# Altium schematic sheet THR_V1.SchDoc(THR_V1)
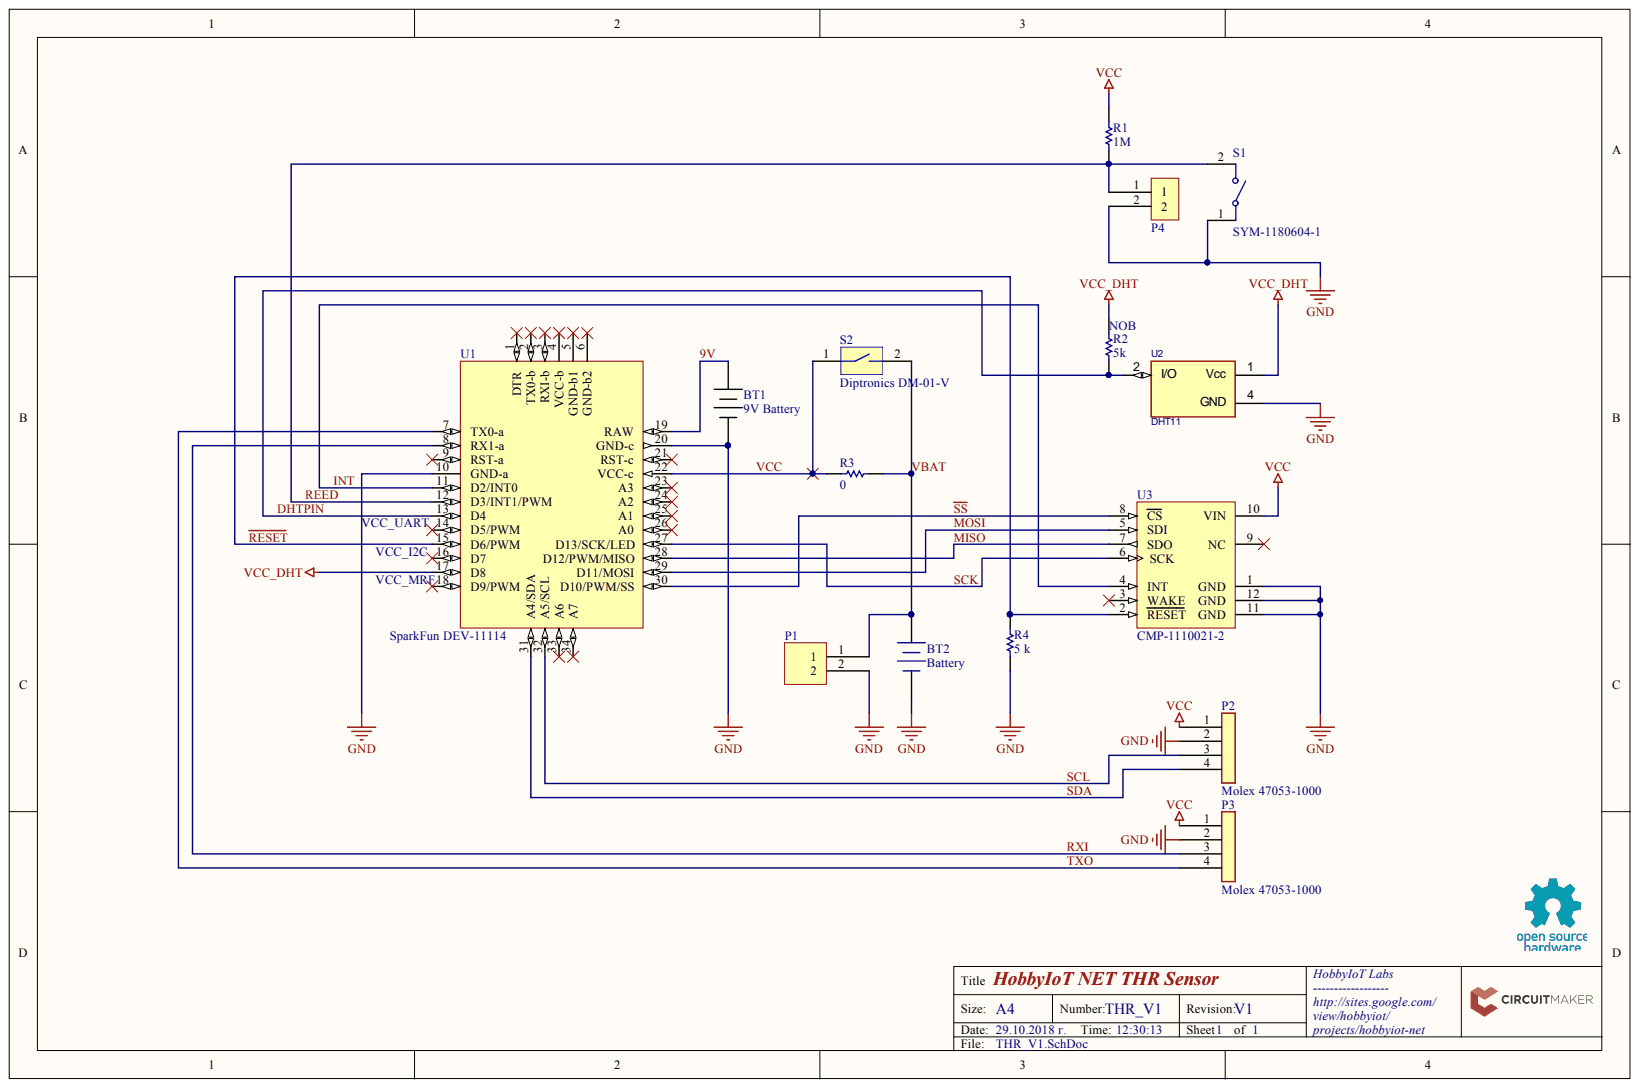
[diagram p0: THR_V1.SchDoc]

## Parts
BT1  9V Battery  [PCB-b0ysiftp69igi77ywnbr-1]
BT2  Battery  [108-holes]
P1  JST B2B-PH-K-S(LF)(SN)  [PCB-gpdi66yn1jko56crxrq7-1]  (value not drawn)
P2  Molex 47053-1000  [PCB-r6ljhe4gry6dgah4l7og-1]
P3  Molex 47053-1000  [PCB-r6ljhe4gry6dgah4l7og-1]
P4  TE Connectivity 87220-2  [2 POS HDR]  (value not drawn)
R1  1M  [RESC1608X55X30LL15T15]
R2  5k  [RESC1608X55X30LL15T15]
R3  0  [RESC1608X55X30LL15T15]
R4  5 k  [RESC1608X55X30LL15T15]
S1  SYM-1180604-1  [PCB-st9at6ls1ff1te26vloo-1]
S2  Diptronics DM-01-V  Manufacturer Part Number: DM-01-V  [PCB-hx7lme7bhq0swr2xcdxd-1]
U1  SparkFun DEV-11114  Manufacturer Part Number: DEV-11114  [PCBComponent_1 - duplicate]
U2  DHT11  [PCB-2j4abk0z7nv5d9pbfq6c-1]
U3  CMP-1110021-2  [MRF24J40MA]

## Nets
GND: BT1.2 BT2.2 P1.2 P2.2 P3.2 P4.2 R4.1 S1.1 U1.10(GND-a) U1.20(GND-c) U2.4 U3.1(GND) U3.11(GND) U3.12(GND)
VCC_DHT: R2.2 U1.17(D8) U2.1
9V: BT1.1 U1.19(RAW)
DHTPIN: R2.1 U1.13(D4) U2.2
INT: U1.11(D2/INT0) U3.4(INT)
MISO: U1.28(D12/PWM/MISO) U3.7(SDO)
MOSI: U1.29(D11/MOSI) U3.5(SDI)
~{RESET}: R4.2 U1.15(D6/PWM) U3.2(RESET)
REED: P4.1 R1.1 S1.2 U1.12(D3/INT1/PWM)
RXI: P3.3 U1.8(RX1-a)
~{SS}: U1.30(D10/PWM/SS) U3.8(CS)
SCK: U1.27(D13/SCK/LED) U3.6(SCK)
SCL: P2.3 U1.32(A5/SCL)
SDA: P2.4 U1.31(A4/SDA)
TXO: P3.4 U1.7(TX0-a)
VBAT: BT2.1 P1.1 R3.1 S2.2
VCC: P2.1 P3.1 R1.2 R3.2 S2.1 U1.22(VCC-c) U3.10(VIN)

Not connected: U1 1(DTR) 2(TX0-b) 3(RXI-b) 4(VCC-b) 5(GND-b1) 6(GND-b2) 9(RST-a) 14(D5/PWM) 16(D7) 18(D9/PWM) 21(RST-c) 23(A3) 24(A2) 25(A1) 26(A0) 33(A6) 34(A7); U3 3(WAKE) 9(NC)

## Library data of the parts
BT1 = CMP-fb36323cdf4924f1-5: Manufacturer Part Number: EN22; Jacket Material: Metal; Lead-Free Status: Contains Lead; Output Voltage: 9.00 V; Component Kind: Standard; Description: 9v Battery; Library Name: CommunityVault; OctopartID: fb36323cdf4924f1; Octopart URL: http://octopart.com/en22-energizer-281; REACH SVHC Compliance: No SVHC; RoHS: Not Applicable; Size-Height: 46.4 mm; Size-Width: 17.5 mm; Voltage Rating (DC): 9.00 V; Weight: 45.4 g
BT2 = CMP-a46e6d64aa3a7ba9-5: Cell holder for CR2 and ½AA cells; Manufacturer Part Number: 108; Lead-Free Status: Lead Free; Component Kind: Standard; Contact Material: Steel; Library Name: CommunityVault; Material: Plastic; Mounting Style: Through Hole, PCB, Snap-In; Octopart URL: http://octopart.com/108-keystone-11058; REACH SVHC Compliance: No SVHC; RoHS: Compliant
P1 = CMP-642947-7: PH Series 2 Position 2 mm Pitch Through Hole Top Entry Shrouded Header; Contact Resistance: 10.0 mΩ; Current Rating: 2.00 A; Gender: Male; Insulation Resistance: 1.00 MΩ; Lead-Free Status: Lead Free; Number of Contacts: 2; Pin Pitch: 2.00 mm; Voltage Rating (AC): 100 V; Component Kind: Standard; Contact Material: Brass; Contact Plating: Tin; Housing Color: Natural; Housing Material: Nylon; Library Name: CommunityVault; Manufacturer Part Number: B2B-PH-K-S(LF)(SN); Mounting Style: Through Hole, Vertical; Number of Positions: 2; Number of Rows: 1; OctopartID: a21c6b154b5e7ddb; Octopart URL: http://octopart.com/b2b-ph-k-s%28lf%29%28sn%29-jst-248868; Packaging: Bulk; REACH SVHC Compliance: No SVHC; RoHS: Compliant; Size-Height: 6.00 mm; Size-Length: 5.90 mm; Size-Width: 4.50 mm
P2 P3 = CMP-b5a27ec055876a65-1: Conn; Rect; Header; Unshrouded; 4 Pos; 2.54mm Pitch; 1-Row; Thru-Hole; BergStik; Manufacturer Part Number: 68002-404HLF; Gender: Male; Component Kind: Standard; Library Name: CommunityVault; Mounting Style: Solder; OctopartID: b5a27ec055876a65; Octopart URL: http://octopart.com/68002-404hlf-fci-40420675; RoHS: Compliant
P4 = CMP-f83d336e9dea27fa-9: Header; Standard; PC Board; Single; 2; 0.025 in.; 0.125 in.; Square; 0.1 in.; Gender: Male; 2: 2; Color: Black; Component Kind: Standard; Contact Material: Phosphor Bronze; Library Name: CommunityVault; Number of Rows: 1; OctopartID: d26019af69370da7; Packaging: Bulk; RoHS: Compliant
R1 R3 = CMP-3652450-2: Thick Film Resistors - SMD 0603 107ohms 1% Tol; Lead-Free Status: Contains Lead; Power Rating: 0.1 W; Resistance: 5 k; Voltage Rating (DC): 50.0 V; Case/Package: 0603; Component Kind: Standard; Library Name: CommunityVault; Lifecycle Status: Not Listed by Manufacturer; Mounting Style: Surface Mount; OctopartID: e4812ec668333b46; Octopart Url: https://octopart.com/erj-3ekf1070v-panasonic-55421396; REACH SVHC Compliance: No SVHC; Resistance Tolerance: ±1%; RoHS: Compliant; Size-Height: 0.00045 m; Size-Length: 0.0016 m; Size-Width: 0.0008 m
R2 R4 = CMP-3652450-2: Thick Film Resistors - SMD 0603 107ohms 1% Tol; Lead-Free Status: Contains Lead; Power Rating: 0.1 W; Voltage Rating (DC): 50.0 V; Case/Package: 0603; Component Kind: Standard; Library Name: CommunityVault; Lifecycle Status: Not Listed by Manufacturer; Mounting Style: Surface Mount; OctopartID: e4812ec668333b46; Octopart Url: https://octopart.com/erj-3ekf1070v-panasonic-55421396; REACH SVHC Compliance: No SVHC; Resistance: 5 k; Resistance Tolerance: ±1%; RoHS: Compliant; Size-Height: 0.00045 m; Size-Length: 0.0016 m; Size-Width: 0.0008 m
S1 = CMP-422a887087829ded-1: MDSM4R Series 500 mA 200 V SPST-NO PCB Surface Mount Reed Switch; Manufacturer Part Number: MDSM-4R-12-18; Lead-Free Status: Lead Free; Component Kind: Standard; Library Name: CommunityVault; Mounting Style: Surface Mount; Octopart URL: http://octopart.com/mdsm-4r-12-18-littelfuse-55632078; Packaging: Cut Tape (CT); RoHS: Compliant; Size-Length: 19.3 mm
S2 = CMP-38c8f0137083e70f-1: Switch DIP OFF ON SPST 1 Raised Slide 0.025A 24VDC Gull Wing SMD Tube; Component Kind: Standard; Library Name: CommunityVault; Mounting Style: Surface Mount; OctopartID: 38c8f0137083e70f; Octopart URL: https://octopart.com/dm-01-v-diptronics-30502189
U1 = CMP-c37d092409061cba-17: Atmega328 Arduino Pro Mini; Component Kind: Standard; Library Name: CommunityVault
U2 = CMP-a3206bddb0c97ce7-5: DHT11 Digital humidity and temperature sensor; Manufacturer Part Number: 386; Lead-Free Status: Contains Lead; Supply Voltage (DC): 14.0 V; Component Kind: Standard; Library Name: CommunityVault; Mounting Style: Flange; OctopartID: a3206bddb0c97ce7; Octopart URL: http://octopart.com/386-adafruit+industries-32978575; RoHS: Compliant; Size-Diameter: 0.00584 m; Size-Length: 0.0159 m
U3 = CMP-1110021-2: 2.4 GHz IEEE Std. 802.15.4, RF Transceiver 12-Pin Module, Industrial Temperature; Data Rate: 250000.0 bps; Lead-Free Status: Lead Free; Supply Current: 0.023 A; Component Kind: Standard; Library Name: CommunityVault; Lifecycle Status: Active; Number of Pins: 12; OctopartID: 0d83c4f7ff6dd244; Octopart Url: https://octopart.com/mrf24j40ma-i%2Frm-microchip-8312712; REACH SVHC Compliance: No SVHC; RoHS: Compliant
